# Altium schematic sheet 08-DIPSwitches_I2C.SchDoc(08-DIPSwitches_I2C)
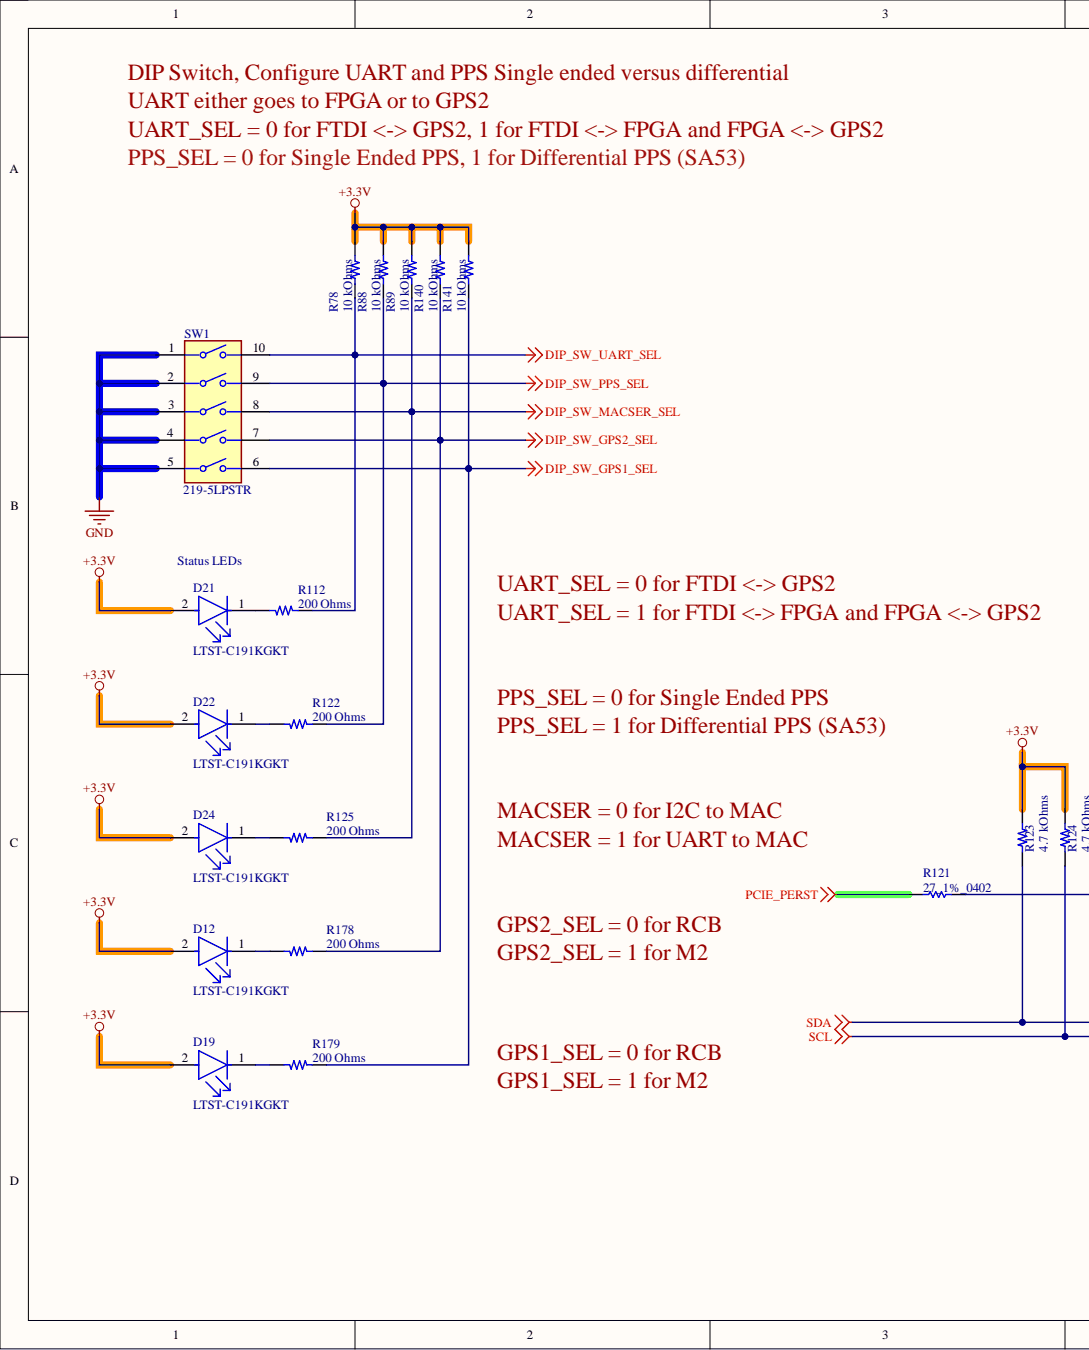
[diagram p0: 08-DIPSwitches_I2C.SchDoc, left]
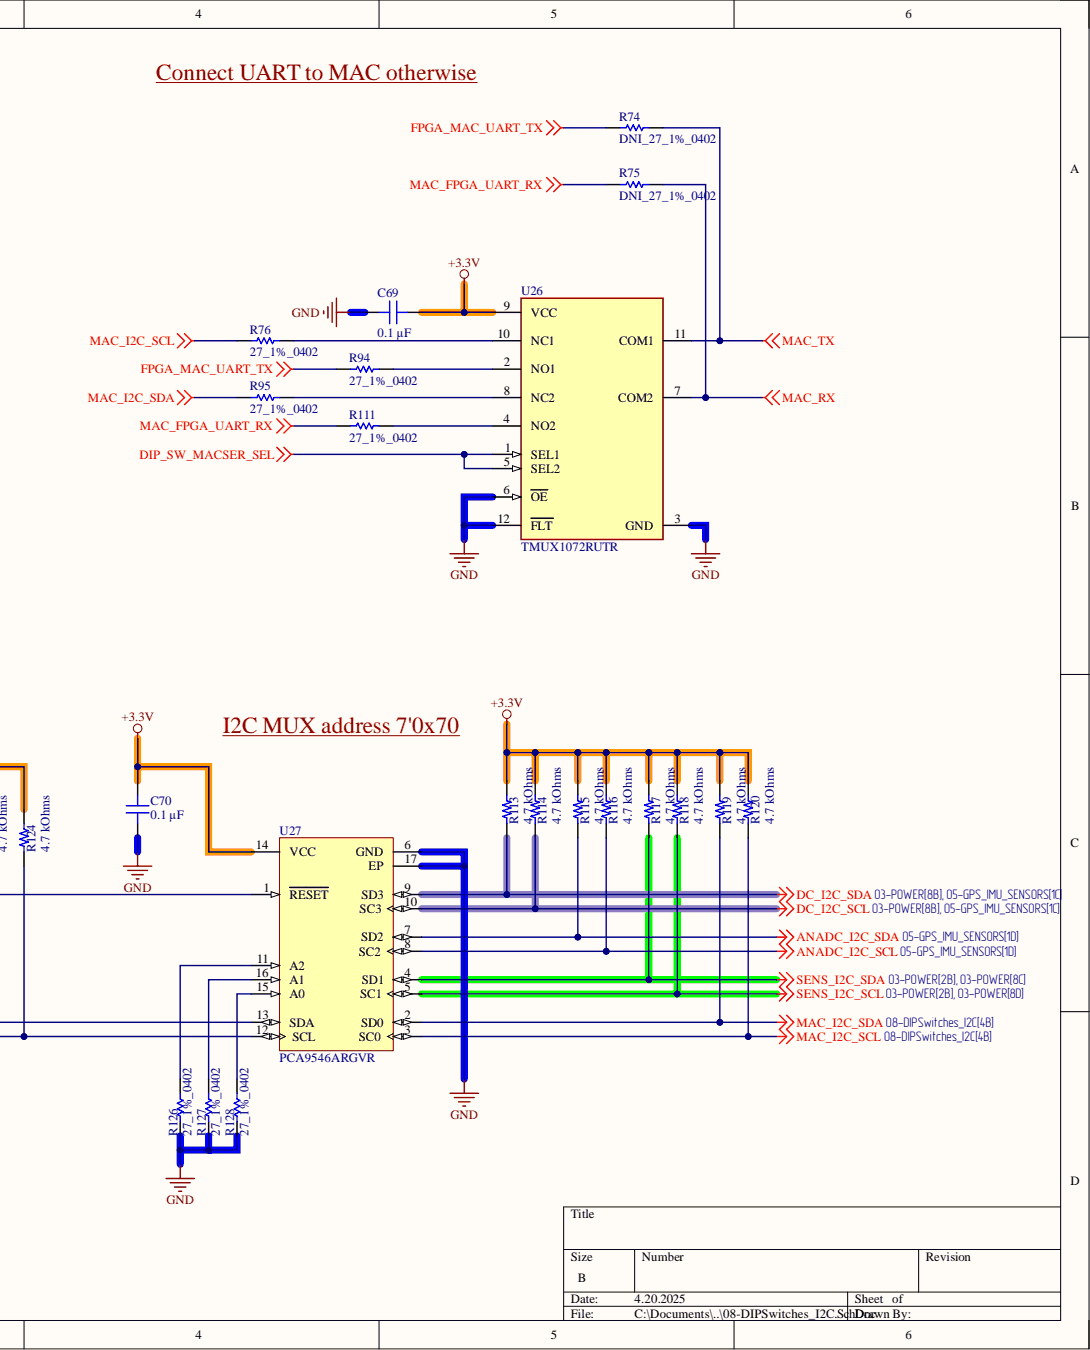
[diagram p1: 08-DIPSwitches_I2C.SchDoc, right]

## Parts
C69  0.1 µF  [CAP 0402_1005]
C70  0.1 µF  [CAP 0402_1005]
D12  LTST-C191KGKT  [FP-LTST-C191KGKT-MFG]
D19  LTST-C191KGKT  [FP-LTST-C191KGKT-MFG]
D21  LTST-C191KGKT  [FP-LTST-C191KGKT-MFG]
D22  LTST-C191KGKT  [FP-LTST-C191KGKT-MFG]
D24  LTST-C191KGKT  [FP-LTST-C191KGKT-MFG]
R74  DNI_27_1%_0402  [RESC1005X40X25NL05T05]  (no BOM)
R75  DNI_27_1%_0402  [RESC1005X40X25NL05T05]  (no BOM)
R76  27_1%_0402  [RESC1005X40X25NL05T05]
R78  10 kOhms  [YAGEO RES 0402_1005]
R88  10 kOhms  [YAGEO RES 0402_1005]
R89  10 kOhms  [YAGEO RES 0402_1005]
R94  27_1%_0402  [RESC1005X40X25NL05T05]
R95  27_1%_0402  [RESC1005X40X25NL05T05]
R111  27_1%_0402  [RESC1005X40X25NL05T05]
R112  200 Ohms  [YAGEO RES 0402_1005]
R113  4.7 kOhms  [YAGEO RES 0402_1005]
R114  4.7 kOhms  [YAGEO RES 0402_1005]
R115  4.7 kOhms  [YAGEO RES 0402_1005]
R116  4.7 kOhms  [YAGEO RES 0402_1005]
R117  4.7 kOhms  [YAGEO RES 0402_1005]
R118  4.7 kOhms  [YAGEO RES 0402_1005]
R119  4.7 kOhms  [YAGEO RES 0402_1005]
R120  4.7 kOhms  [YAGEO RES 0402_1005]
R121  27_1%_0402  [RESC1005X40X25NL05T05]
R122  200 Ohms  [YAGEO RES 0402_1005]
R123  4.7 kOhms  [YAGEO RES 0402_1005]
R124  4.7 kOhms  [YAGEO RES 0402_1005]
R125  200 Ohms  [YAGEO RES 0402_1005]
R126  27_1%_0402  [RESC1005X40X25NL05T05]
R127  27_1%_0402  [RESC1005X40X25NL05T05]
R128  27_1%_0402  [RESC1005X40X25NL05T05]
R140  10 kOhms  [YAGEO RES 0402_1005]
R141  10 kOhms  [YAGEO RES 0402_1005]
R178  200 Ohms  [YAGEO RES 0402_1005]
R179  200 Ohms  [YAGEO RES 0402_1005]
SW1  219-5LPSTR  [FP-219-5LPSTR-MFG]
U26  TMUX1072RUTR  [FP-RUT0012A-MFG]
U27  PCA9546ARGVR  [RGV0016A_V]

## Nets
+3.3V: C69.2 C70.2 D12.2 D19.2 D21.2 D22.2 D24.2 R78.1 R88.1 R89.1 R113.2 R114.2 R115.2 R116.2 R117.2 R118.2 R119.2 R120.2 R123.2 R124.2 R140.1 R141.1 U26.9(VCC) U27.14(VCC)
ANADC_I2C_SCL: R116.1 U27.8(SC2)
ANADC_I2C_SDA: R115.1 U27.7(SD2)
DC_I2C_SCL: R114.1 U27.10(SC3)
DC_I2C_SDA: R113.1 U27.9(SD3)
DIP_SW_GPS1_SEL: R141.2 R179.2 SW1.6
DIP_SW_GPS2_SEL: R140.2 R178.2 SW1.7
DIP_SW_MACSER_SEL: R89.2 R125.2 SW1.8 U26.1(SEL1) U26.5(SEL2)
DIP_SW_PPS_SEL: R88.2 R122.2 SW1.9
DIP_SW_UART_SEL: R78.2 R112.2 SW1.10
FPGA_MAC_UART_TX: R74.1 R94.1
GND: C69.1 C70.1 R126.1 R127.1 R128.1 SW1.1 SW1.2 SW1.3 SW1.4 SW1.5 U26.3(GND) U26.6(OE) U26.12(FLT) U27.6(GND) U27.17(EP)
MAC_FPGA_UART_RX: R75.1 R111.1
MAC_I2C_SCL: R76.1 R120.1 U27.3(SC0)
MAC_I2C_SDA: R95.1 R119.1 U27.2(SD0)
MAC_RX: R75.2 U26.7(COM2)
MAC_TX: R74.2 U26.11(COM1)
PCIE_PERST: R121.1
SCL: R124.1 U27.12(SCL)
SDA: R123.1 U27.13(SDA)
SENS_I2C_SCL: R118.1 U27.5(SC1)
SENS_I2C_SDA: R117.1 U27.4(SD1)
NetD12_1: D12.1 R178.1
NetD19_1: D19.1 R179.1
NetD21_1: D21.1 R112.1
NetD22_1: D22.1 R122.1
NetD24_1: D24.1 R125.1
NetR76_2: R76.2 U26.10(NC1)
NetR94_2: R94.2 U26.2(NO1)
NetR95_2: R95.2 U26.8(NC2)
NetR111_2: R111.2 U26.4(NO2)
NetR121_2: R121.2 U27.1(RESET)
NetR126_2: R126.2 U27.11(A2)
NetR127_2: R127.2 U27.16(A1)
NetR128_2: R128.2 U27.15(A0)

## Library data of the parts
C69 C70 = CC0402KRX7R9BB104: CAP CER 0.1UF 50V X7R 0402; manufacturer: Yageo; Database Table Name: Capacitors - Ceramic - 0402; Tolerance: ±10%; Applications: General Purpose; Capacitance: 0.1 µF; Component Kind: Standard; componentlink1description: Datasheet; componentlink1url: https://www.yageo.com/upload/media/product/productsearch/datasheet/mlcc/UPY-GPHC_X7R_6.3V-to-50V_18.pdf; componentlink2description: DigiKey Link; componentlink2url: https://www.digikey.com/en/products/detail/yageo/CC0402KRX7R9BB104/5883371; Device Package: 0402 (1005 Metric); lastupdated: 09.02.2024 20:04:27; Library Name: Celestial Altium Library - altium_library.DbLib; Lifecycle Status: Active; Minimum Order: 10; Mounting Type: Surface Mount, MLCC; Operating Temperature: -55°C ~ 125°C; Package / Case: 0402 (1005 Metric); packaging: TapeAndReel; Part Number: CC0402KRX7R9BB104; Part Status: Active; partid: 8451; price: 0.01; series: CC; Size / Dimension: 0.039" L x 0.020" W (1.00mm x 0.50mm); Supplier 1: DigiKey; Supplier Part Number 1: 311-3566-1-ND; Temperature Coefficient: X7R; Thickness (Max): 0.022" (0.55mm); Value: 0.1 µF; Voltage - Rated: 50V
D12 D19 D21 D22 D24 = CMP-2000-05198-2: LED GREEN CLEAR SMD; Dominant Wavelength: 571nm; Forward Current: 30mA; Forward Voltage: 2V; Lens Color: Colorless; Lens Style: Rectangular; Luminous Intensity: 35mcd; Max Power Dissipation: 75mW; Number of Elements: 1; Reverse Voltage: 5V; Test Current: 20mA; Viewing Angle: 130°; Wavelength: 574nm; Case Code (Imperial): 0603; Case Code (Metric): 1608; Case/Package: 0603; Color: Green; Component Kind: Standard; Depth: 0.8mm; Height: 0.55mm; Illumination Color: Green; Lead Free: Lead Free; Length: 1.6mm; Lens Transparency: Clear; Library Name: Altium Content Vault; Max Operating Temperature: 85°C; Min Operating Temperature: -55°C; Mount: Surface Mount; Number of LEDs: 1; Number of Pins: 2; Package Quantity: 1; Packaging: Tape and Reel; Power Dissipation: 75mW; Power Rating: 75mW; Radiation Hardening: No; Width: 0.8mm
R74-R76 R94 R95 R111 R121 R126-R128 = CMP-2002-08434-1: Manufacturer: Vishay Dale; Part Number: CRCW040227R0FKED; Library Name: Altium Content Vault
  R76 R94 R95 R111 R121 R126-R128: Component Kind: Standard
R78 R88 R89 R140 R141 = RC0402FR-0710KL: RES 10K OHM 1% 1/16W 0402; Manufacturer: Yageo; Database Table Name: Resistors - Surface Mount - 0402; Power (Watts): 0.063W, 1/16W; Temperature Coefficient: ±100ppm/°C; Component Kind: Standard; ComponentLink1Description: Datasheet; ComponentLink1URL: https://www.yageo.com/upload/media/product/productsearch/datasheet/rchip/PYu-RC_Group_51_RoHS_L_10.pdf; ComponentLink2Description: DigiKey Link; ComponentLink2URL: https://www.digikey.com/en/products/detail/yageo/RC0402FR-0710KL/726523; Composition: Thick Film; Device Package: 0402 (1005 Metric); Features: Moisture Resistant; Height - Seated (Max): 0.016" (0.40mm); LastUpdated: 25.07.2024 9:18:11; Library Name: Celestial Altium Library - altium_library.DbLib; Lifecycle Status: Active; Minimum Order: 1; Number of Terminations: 2; Operating Temperature: -55°C ~ 155°C; Package / Case: 0402 (1005 Metric); Packaging: CutTape; Part Number: RC0402FR-0710KL; Part Status: Active; PartId: 17593; Price: 0.01; Resistance: 10 kOhms; Series: RC_L; Size / Dimension: 0.039" L x 0.020" W (1.00mm x 0.50mm); Supplier 1: DigiKey; Supplier Device Package: 0402; Supplier Part Number 1: 311-10.0KLRCT-ND; Tolerance: ±1%; Value: 10 kOhms
R112 R122 R125 R178 R179 = RC0402FR-07200RL: RES 200 OHM 1% 1/16W 0402; Manufacturer: Yageo; Database Table Name: Resistors - Surface Mount - 0402; Power (Watts): 0.063W, 1/16W; Temperature Coefficient: ±100ppm/°C; Component Kind: Standard; ComponentLink1Description: Datasheet; ComponentLink1URL: https://www.yageo.com/upload/media/product/productsearch/datasheet/rchip/PYu-RC_Group_51_RoHS_L_10.pdf; ComponentLink2Description: DigiKey Link; ComponentLink2URL: https://www.digikey.com/en/products/detail/yageo/RC0402FR-07200RL/2827569; Composition: Thick Film; Device Package: 0402 (1005 Metric); Features: Moisture Resistant; Height - Seated (Max): 0.016" (0.40mm); LastUpdated: 25.07.2024 9:18:11; Library Name: Celestial Altium Library - altium_library.DbLib; Lifecycle Status: Active; Minimum Order: 1; Number of Terminations: 2; Operating Temperature: -55°C ~ 155°C; Package / Case: 0402 (1005 Metric); Packaging: CutTape; Part Number: RC0402FR-07200RL; Part Status: Active; PartId: 17915; Price: 0.01; Resistance: 200 Ohms; Series: RC_L; Size / Dimension: 0.039" L x 0.020" W (1.00mm x 0.50mm); Supplier 1: DigiKey; Supplier Device Package: 0402; Supplier Part Number 1: 311-200LRCT-ND; Tolerance: ±1%; Value: 200 Ohms
R113-R120 R123 R124 = RC0402FR-074K7L: RES 4.7K OHM 1% 1/16W 0402; Manufacturer: Yageo; Database Table Name: Resistors - Surface Mount - 0402; Power (Watts): 0.063W, 1/16W; Temperature Coefficient: ±100ppm/°C; Component Kind: Standard; ComponentLink1Description: Datasheet; ComponentLink1URL: https://www.yageo.com/upload/media/product/productsearch/datasheet/rchip/PYu-RC_Group_51_RoHS_L_10.pdf; ComponentLink2Description: DigiKey Link; ComponentLink2URL: https://www.digikey.com/en/products/detail/yageo/RC0402FR-074K7L/2827563; Composition: Thick Film; Device Package: 0402 (1005 Metric); Features: Moisture Resistant; Height - Seated (Max): 0.016" (0.40mm); LastUpdated: 25.07.2024 9:18:11; Library Name: Celestial Altium Library - altium_library.DbLib; Lifecycle Status: Active; Minimum Order: 1; Number of Terminations: 2; Operating Temperature: -55°C ~ 155°C; Package / Case: 0402 (1005 Metric); Packaging: CutTape; Part Number: RC0402FR-074K7L; Part Status: Active; PartId: 17603; Price: 0.01; Resistance: 4.7 kOhms; Series: RC_L; Size / Dimension: 0.039" L x 0.020" W (1.00mm x 0.50mm); Supplier 1: DigiKey; Supplier Device Package: 0402; Supplier Part Number 1: 311-4.7KLRCT-ND; Tolerance: ±1%; Value: 4.7 kOhms
SW1 = CMP-07018-000003-1: SWITCH SLIDE DIP SPST 100MA 20V; Actuator Type: Slide (Standard); Electrical Life: 2000Cycles; Number of Positions: 5; Pitch: 0.1inch; Washable: Yes; Component Kind: Standard; Contact Material: Copper; Contact Plating: Gold; Current Rating: 100mA; Depth: 9.91mm; Lead Free: Lead Free; Length: 0.558inch; Library Name: Altium Content Vault; Max Current Rating: 100mA; Max Operating Temperature: 85°C; Max Voltage Rating (DC): 20V; Mechanical Life: 2000Cycles; Min Operating Temperature: -55°C; Mount: Surface Mount; Orientation: Straight; Package Quantity: 1000; Packaging: Tape and Reel; Radiation Hardening: No; Termination: Gull Wing; Throw Configuration: SPST; Voltage Rating (DC): 20V
U26 = CMP-04892-000114-1: IC SWITCH SPDT DUAL 12UQFN; -3db Bandwidth: 1.2GHz; Number of Channels: 2; On-State Resistance: 18R; Component Kind: Standard; Library Name: Altium Content Vault; Max Operating Temperature: 125°C; Min Operating Temperature: -40°C; Number of Circuits: 2; Number of Pins: 12; Packaging: Tape and Reel; RoHS Compliant: Yes
U27 = CMP-0314-00065-2: 4-Channel I2C and SMBus Multiplexer with Reset Functions, 2.3 to 5.5 V, -40 to 85 degC, 16-pin VQFN (RGV), Green (RoHS & no Sb/Br); Manufacturer: Texas Instruments; 5V Tolerant I/O: Yes; Channel Width: 4; fSCLK(Max)(MHz): 100, 400; I2C Address: 1110 xxx; Max Frequency(kHz): 400; Simultaneously Active Channels: 1 to 4; SubFamily: I2C Multiplexer, Switch; tpd max(ns): 0.3, 1; VCC(Max)(V): 5.5; VCC(Min)(V): 2.3; Component Kind: Standard; ComponentLink1Description: Datasheet; ComponentLink1URL: http://www.ti.com/general/docs/lit/getliterature.tsp?genericPartNumber=PCA9546A&fileType=pdf; ComponentLink2Description: Package Specification; ComponentLink2URL: http://www.ti.com/litv/pdf/mpds178g; DatasheetVersion: SCPS148E; Eco-Plan: Green (RoHS & no Sb/Br); Library Name: Altium Content Vault; Mounting Technology: Surface Mount; Open Drain I/O Type: Yes; PackageDescription: 16-Pin Plastic Quad Flat No-Lead, 4 x 4 mm Body, 0.65 mm Pitch, 2.16 x 2.16 mm Exposed Pad; PackageReference: RGV0016A; PackageVersion: revF, Jun-2011; PartNumber: PCA9546ARGVR; Reset Input: Yes; Vcc range(V): 2.3 to 5.5
